# Altium schematic sheet Sheet2.SchDoc(Sheet2)
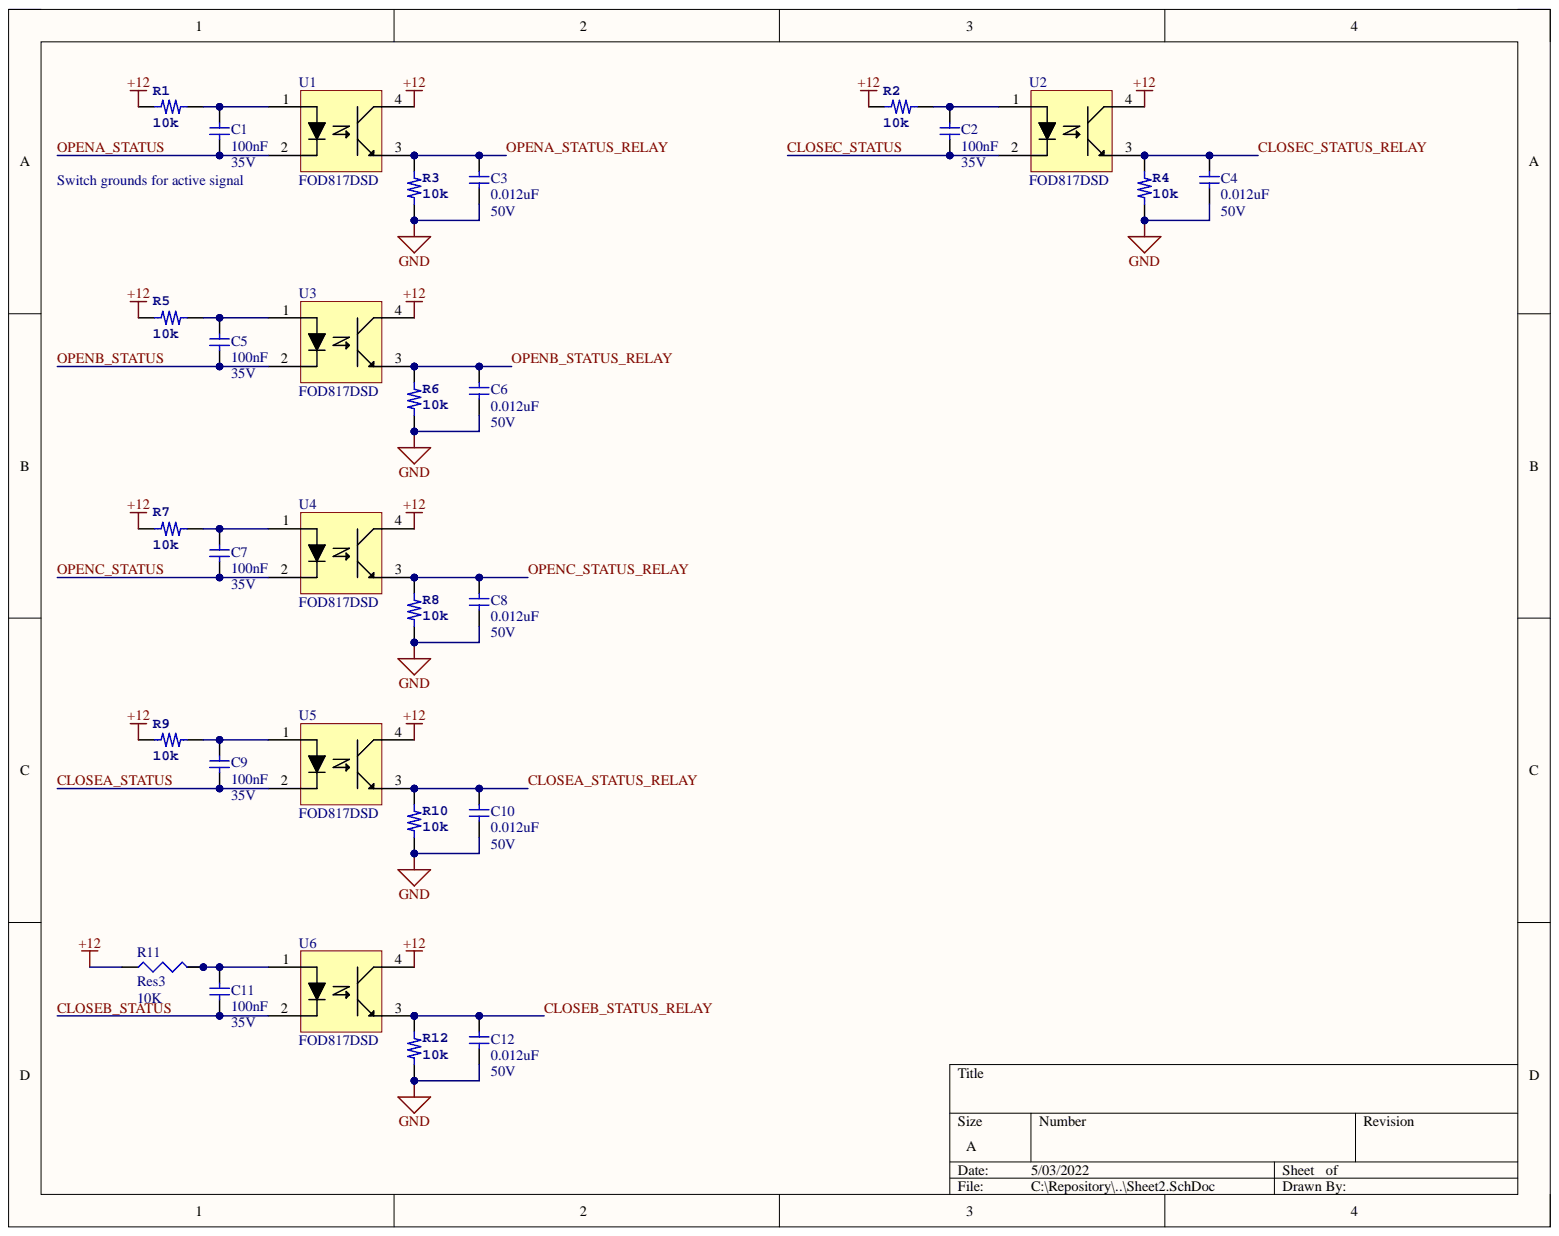
[diagram p0: Sheet2.SchDoc]

## Parts
C1  100nF  Voltage: 35V  [C0603N]
C2  100nF  Voltage: 35V  [C0603N]
C3  0.012uF  Voltage: 50V  [C0805K]
C4  0.012uF  Voltage: 50V  [C0805K]
C5  100nF  Voltage: 35V  [C0603N]
C6  0.012uF  Voltage: 50V  [C0805K]
C7  100nF  Voltage: 35V  [C0603N]
C8  0.012uF  Voltage: 50V  [C0805K]
C9  100nF  Voltage: 35V  [C0603N]
C10  0.012uF  Voltage: 50V  [C0805K]
C11  100nF  Voltage: 35V  [C0603N]
C12  0.012uF  Voltage: 50V  [C0805K]
R1  10k  [R0603N]
R2  10k  [R0603N]
R3  10k  [R0603N]
R4  10k  [R0603N]
R5  10k  [R0603N]
R6  10k  [R0603N]
R7  10k  [R0603N]
R8  10k  [R0603N]
R9  10k  [R0603N]
R10  10k  [R0603N]
R11  Res3  value 10K  [J1-0603]
R12  10k  [R0603N]
U1  FOD817DSD  [4-SMD GullWing]
U2  FOD817DSD  [4-SMD GullWing]
U3  FOD817DSD  [4-SMD GullWing]
U4  FOD817DSD  [4-SMD GullWing]
U5  FOD817DSD  [4-SMD GullWing]
U6  FOD817DSD  [4-SMD GullWing]

## Nets
+12: R1.2 R2.2 R5.2 R7.2 R9.2 R11.1 U1.4 U2.4 U3.4 U4.4 U5.4 U6.4
GND: C3.2 C4.2 C6.2 C8.2 C10.2 C12.2 R3.1 R4.1 R6.1 R8.1 R10.1 R12.1
CLOSEA_STATUS: C9.2 U5.2
CLOSEA_STATUS_RELAY: C10.1 R10.2 U5.3
CLOSEB_STATUS: C11.2 U6.2
CLOSEB_STATUS_RELAY: C12.1 R12.2 U6.3
CLOSEC_STATUS: C2.2 U2.2
CLOSEC_STATUS_RELAY: C4.1 R4.2 U2.3
OPENA_STATUS: C1.2 U1.2
OPENA_STATUS_RELAY: C3.1 R3.2 U1.3
OPENB_STATUS: C5.2 U3.2
OPENB_STATUS_RELAY: C6.1 R6.2 U3.3
OPENC_STATUS: C7.2 U4.2
OPENC_STATUS_RELAY: C8.1 R8.2 U4.3
NetC1_1: C1.1 R1.1 U1.1
NetC2_1: C2.1 R2.1 U2.1
NetC5_1: C5.1 R5.1 U3.1
NetC7_1: C7.1 R7.1 U4.1
NetC9_1: C9.1 R9.1 U5.1
NetC11_1: C11.1 R11.2 U6.1

## Library data of the parts
C1 C2 C5 C7 C9 C11 = CAP_0603: CAP CER 10% 0603; Temp: X7R; Alternate Allowed: ?; Component Kind: Standard; Manufacturer: ?; Mount: SMT; Part Number: ?; PartNumber-Prime: ?; Library Name: ASD_MASTER.SCHLIB
C3 C4 C6 C8 C10 C12 = CAP_0805: CAP CER 10% 0805; Alternate Allowed: ?; Component Kind: Standard; Manufacturer: ?; Mount: SMT; PartNumber: ?; PartNumber-Prime: ?; Temp: ?; Library Name: ASD_MASTER.SCHLIB
R1 R2 R5 R7 R9 = RES_1/10W_0603_1%: RES SMD 1/10W 0603 1%; Alternate Allowed: ?; Component Kind: Standard; Manufacturer: ?; Manufacturer Part Number: ?; Mount: SMT; Part Number-Prime: ?; Power: 1/10W; Tolerance: 1%; Library Name: ASD_MASTER.SCHLIB
R3 R4 R6 R8 R10 R12 = RES_1/10W_0603_0.1%: RES SMD 1/10W 0603 0.1%; Alternate Allowed: ?; Component Kind: Standard; Manufacturer: ?; Manufacturer Part Number: ?; Mount: SMT; Part Number-Prime: ?; Power: 1/10W; Tolerance: 0.1%; Library Name: ASD_MASTER.SCHLIB
R11 = Res3: Resistor; Component Kind: Standard; LatestRevisionDate: 29-May-2009; LatestRevisionNote: IPC-7351 Footprint Added.; Library Name: Miscellaneous Devices.IntLib; PackageDescription: Chip Resistor; PackageReference: J1-0603; Published: 8-Jun-2000; Signal Integrity: Res; Simulation: RESISTOR; Publisher: Altium Limited
U1-U6 = 108-00030-001: OPTOISOLATOR 5KV TRANSISTOR 4SMD; Manufacturer: Fairchild; Temp: -55C, +110C; Component Kind: Standard; Manufacturer Part Number: FOD817DSD; Mount: SMT; Part Number-ASD: 108-00030-001; Part Number-Prime: ?; Price: 0.30; Supplier 1: Digi-Key; Supplier Part Number 1: FOD817DSDCT-ND; Library Name: ASD.IntLib
